# Altium schematic sheet Sheet1.SchDoc
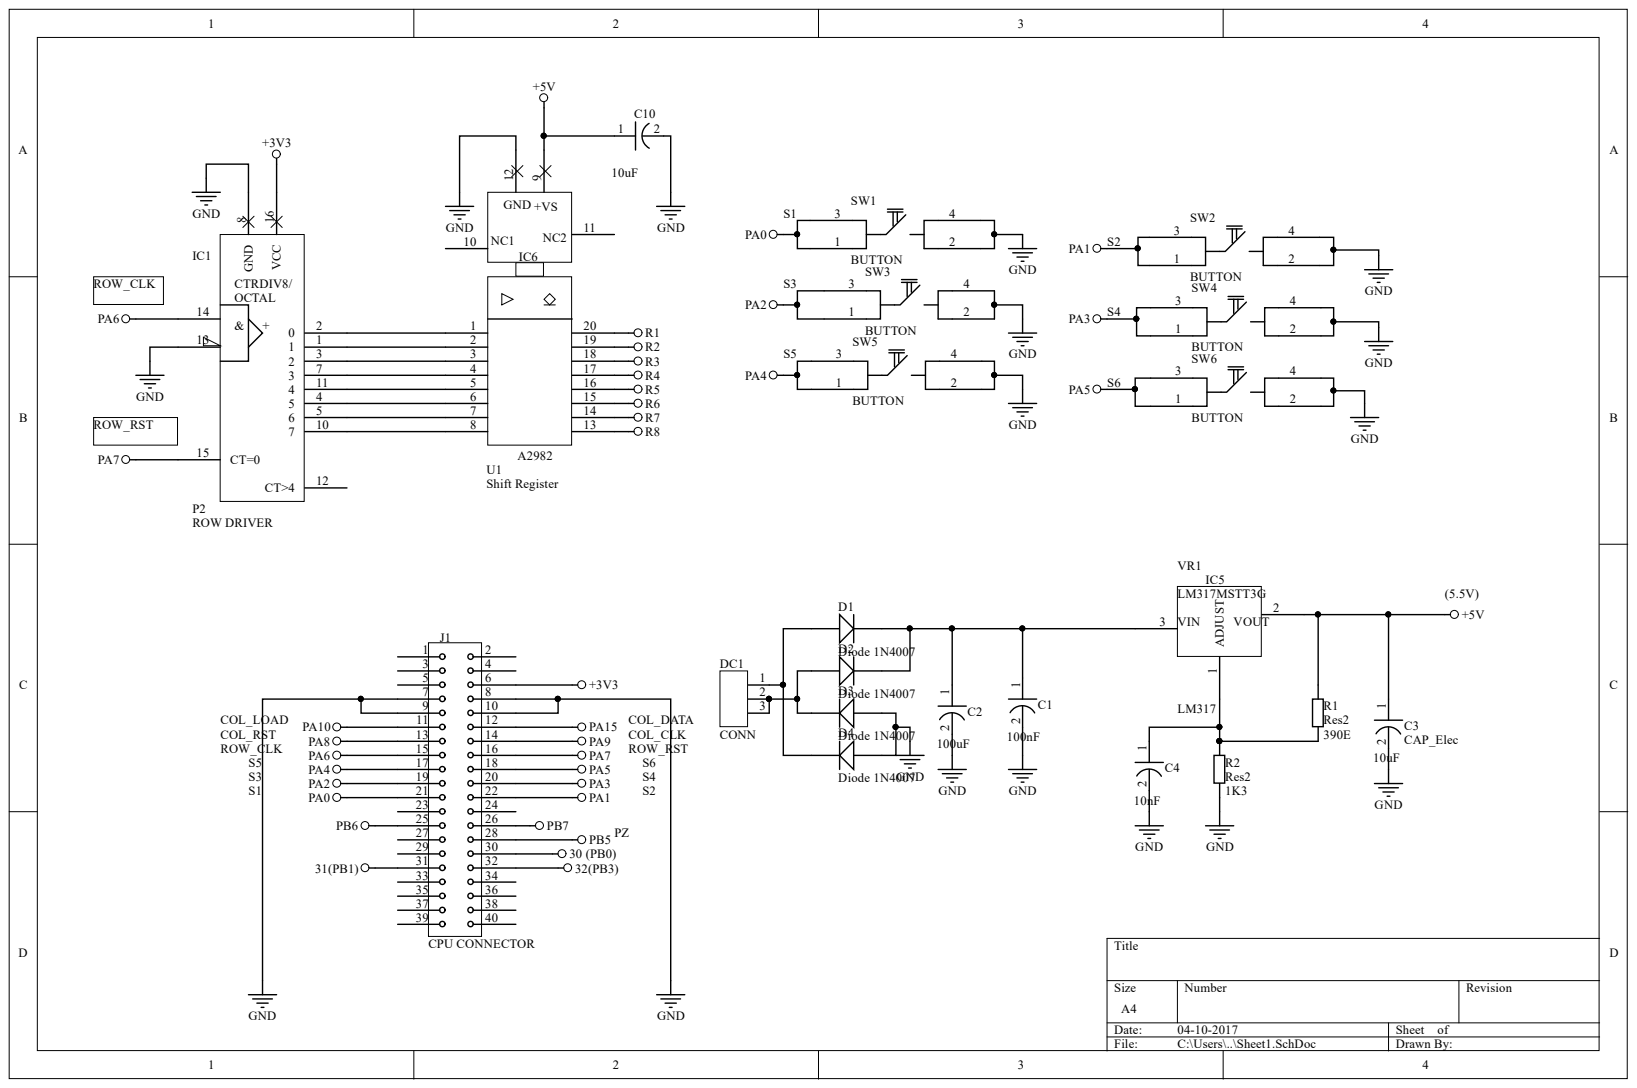
[diagram p0: Sheet1.SchDoc]

## Parts
C1  CAP_Elec  [CAP_Electrolytic]  (value not drawn)
C2  CAP_Elec  [CAP_Electrolytic]  (value not drawn)
C3  CAP_Elec  [CAP_Electrolytic]
C4  CAP_Elec  [CAP_Electrolytic]  (value not drawn)
C10  CAP_Elec  [CAP_Electrolytic]  (value not drawn)
D1  Diode 1N4007  [DO-41]
D2  Diode 1N4007  [DO-41]
D3  Diode 1N4007  [DO-41]
D4  Diode 1N4007  [DO-41]
DC1  CONN  [DC_SOCKET]
P2  ROW DRIVER  [DIP16_300]
R1  Res2  value 390E  [AXIAL-0.4]
R2  Res2  value 1K3  [AXIAL-0.4]
SW1  BUTTON  [Button 0.3x0.2]
SW2  BUTTON  [Button 0.3x0.2]
SW3  BUTTON  [Button 0.3x0.2]
SW4  BUTTON  [Button 0.3x0.2]
SW5  BUTTON  [Button 0.3x0.2]
SW6  BUTTON  [Button 0.3x0.2]
U1  Shift Register  [SOIC_20]
VR1  LM317  [LM317]
  CPU CONNECTOR  [CPU Connector]

## Nets
30 (PB0): .30
31(PB1): .31
32(PB3): .32
+3V3: P2.16(VCC) .6
+5V: C3.1 C10.1 R1.2 U1.9 VR1.2
GND: C1.2 C2.2 C3.2 C4.2 C10.2 D3.1 D4.1 P2.8(GND) P2.13 R2.1 SW1.2 SW1.4 SW2.2 SW2.4 SW3.2 SW3.4 SW4.2 SW4.4 SW5.2 SW5.4 SW6.2 SW6.4 U1.12 .7 .8 .9 .10
Net_1: .1
Net_2: .2
Net_3: .3
Net_4: .4
Net_5: .5
Net_23: .23
Net_24: .24
Net_27: .27
Net_29: .29
Net_33: .33
Net_34: .34
Net_35: .35
Net_36: .36
Net_37: .37
Net_38: .38
Net_39: .39
Net_40: .40
PA0: SW1.1 SW1.3 .21
PA2: SW3.1 SW3.3 .19
PA4: SW5.1 SW5.3 .17
PA6: P2.14 .15
PA7: P2.15(CT=0) .16
PA8: .13
PA9: .14
PA10: .11
PA15: .12
PB5: .28
PB6: .25
PB7: .26
R1: U1.20
R2: U1.19
R3: U1.18
R4: U1.17
R5: U1.16
R6: U1.15
R7: U1.14
R8: U1.13
S2: SW2.1 SW2.3 .22
S4: SW4.1 SW4.3 .20
S6: SW6.1 SW6.3 .18
NetC1_1: C1.1 C2.1 D1.2 D2.2 VR1.3
NetC4_1: C4.1 R1.1 R2.2 VR1.1
NetD1_1: D1.1 D4.2 DC1.1
NetD2_1: D2.1 D3.2 DC1.2 DC1.3
NetP2_1: P2.1 U1.2
NetP2_2: P2.2 U1.1
NetP2_3: P2.3 U1.3
NetP2_4: P2.4 U1.6
NetP2_5: P2.5 U1.7
NetP2_7: P2.7 U1.4
NetP2_10: P2.10 U1.8
NetP2_11: P2.11 U1.5
(5.5V):
COL_CLK:
COL_DATA:
COL_LOAD:
COL_RST:
PZ:
ROW_CLK:
ROW_RST:
S1:
S3:
S5:

Not connected: P2 12(CT>4); U1 10 11(NC2)

## Library data of the parts
C1-C4 C10 = CAP_Elec: CAP_ELECTROLYTIC; Component Kind: Standard; Library Name: Integrated_Library.IntLib
  C1: : 100nF
  C2: : 100uF
  C3 C10: : 10uF
  C4: : 10nF
D1-D4 = Diode 1N4007: 1 Amp General Purpose Rectifier; Code_JEDEC: DO-204-AL; Component Kind: Standard; ComponentLink1Description: Manufacturer Link; ComponentLink1URL: http://www.fairchildsemi.com/; ComponentLink2Description: Datasheet; ComponentLink2URL: http://www.fairchildsemi.com/ds/1N%2F1N4001.pdf; DatasheetVersion: 1998; LatestRevisionDate: 17-Jul-2002; LatestRevisionNote: Re-released for DXP Platform.; Library Name: Miscellaneous Devices.IntLib; PackageDescription: Diode, Axial; Body 5.2 x 2.7 mm (LxDia. max), Lead Dia. 0.86 mm (max); PackageReference: DO-41; PackageVersion: Aug-1999; Published: 8-Jun-2000; Signal Integrity: 1N4007; Simulation: 1N4007; Publisher: Altium Limited
DC1 = DC_SOCKET: Component Kind: Standard; Description: DC_SOCKET; Library Name: Integrated_Library1.IntLib
P2 = ROW DRIVER: CD4022B; Component Kind: Standard; LatestRevisionDate: 17-Jul-2002; LatestRevisionNote: Re-released for DXP Platform.; Library Name: Schlib1.SchLib; Publisher: Altium Limited
R1 R2 = Res2: Resistor; Component Kind: Standard; LatestRevisionDate: 17-Jul-2002; LatestRevisionNote: Re-released for DXP Platform.; Library Name: Miscellaneous Devices.IntLib; PackageDescription: Axial Device, Thru-Hole; 2 Leads; 0.4 in Pin Spacing; PackageReference: AXIAL-0.4; Published: 8-Jun-2000; Signal Integrity: Res; Simulation: RESISTOR; Publisher: Altium Limited
U1 = A2982: Component Kind: Standard; Description: Shift Register; LatestRevisionDate: 17-Jul-2002; LatestRevisionNote: Re-released for DXP Platform.; Library Name: Schlib1.SchLib; Publisher: Altium Limited
VR1 = LM317MSTT3G: Voltage Regulator; Component Kind: Standard; LatestRevisionDate: 17-Jul-2002; LatestRevisionNote: Re-released for DXP Platform.; Library Name: Schlib1.SchLib; Publisher: Altium Limited
SW1-SW6 = BUTTON: Component Kind: Standard; LatestRevisionDate: 17-Jul-2002; LatestRevisionNote: Re-released for DXP Platform.; Library Name: Schlib1.SchLib; Publisher: Altium Limited
 = CPU CONNECTOR: Component Kind: Standard; LatestRevisionDate: 17-Jul-2002; LatestRevisionNote: Re-released for DXP Platform.; Library Name: Schlib1.SchLib; Publisher: Altium Limited
